# Altium schematic sheet 2022_AESK_UAV_Power.SchDoc(2022_AESK_UAV_Power)
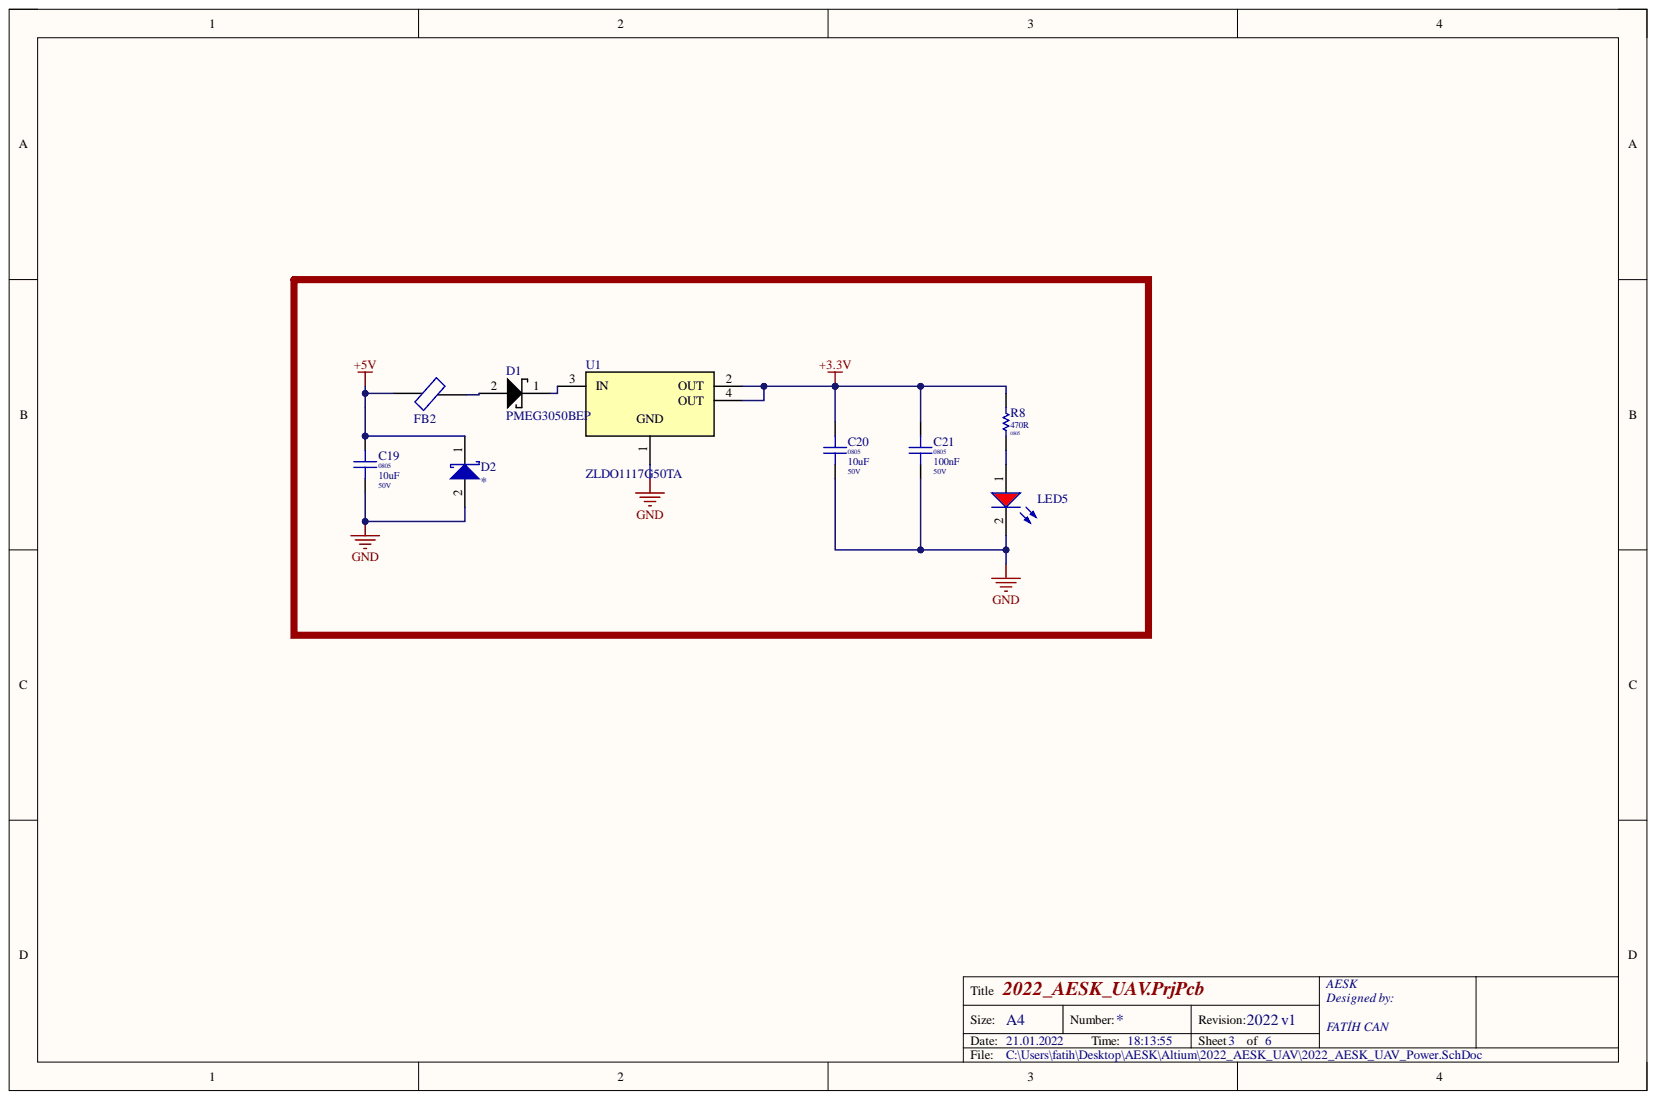
[diagram p0: 2022_AESK_UAV_Power.SchDoc]

## Parts
C19  10uF  Package / Case: 0805  Values: 10uF 50V  Voltage: 50V  [CAPC 0805]
C20  10uF  Package / Case: 0805  Values: 10uF 50V  Voltage: 50V  [CAPC 0805]
C21  100nF  Package / Case: 0805  Values: 100nF 50V  Voltage: 50V  [CAPC 0805]
D1  PMEG3050BEP  [SOD470X100-2N]
D2  (no value)  [DO-214AC, SMA]
FB2  Ferrite Bead  [FCM1608KF-601T03]  (value not drawn)
LED5  (no value)  [LED RED 0805]
R8  470R  Package / Case: 0805  [RESC 0805]
U1  ZLDO1117G50TA  [1117]

## Nets
+3.3V: C20.2 C21.2 R8.2 U1.2(OUT) U1.4(OUT)
+5V: C19.2 D2.1 FB2.2
GND: C19.1 C20.1 C21.1 D2.2 LED5.2 U1.1
NetD1_1: D1.1 U1.3(IN)
NetD1_2: D1.2 FB2.1
NetLED5_1: LED5.1 R8.1

## Library data of the parts
C19 C20 = CAPC0588: Capacitor Ceramic 10uF 50V 0805; Database Table Name: Ceramic Cap$; Value Rating: uF; Category: Capacitor Ceramic; Component Kind: Standard; Part Number: CAPC0588; Value: 10uF; Library Name: Aesk Database Library.DbLib
C21 = CAPC0572: Capacitor Ceramic 100nF 50V 0805; Database Table Name: Ceramic Cap$; Favorites: Favorite; Value Rating: nF; Category: Capacitor Ceramic; Component Kind: Standard; Part Number: CAPC0572; Value: 100nF; Library Name: Aesk Database Library.DbLib
D1 = Schotky Diode: Manufacturer: Nexperia USA; Manufacturer Part Number: PMEG3050BEP,115; Component Kind: Standard; Description: Schotky Diode; Pricing 1: 1=0.44, 10=0.373, 100=0.2786, 500=0.2189, 1000=0.1692 (USD); Stock 1: 62590; Supplier 1: Digi-Key; Supplier Part Number 1: 1727-5207-1-ND; Library Name: 2022_AESK_UAV.SchLib
D2 = Diode0012: Current: FILL!!!; Database Table Name: Diodes$; Diode Type: Schottky; Category: Diode Schottky; Component Kind: Standard; Package / Case: DO-214AC, SMA; Part Number: Diode0012; Speed: FILL!!!; Values: FILL!!!; Voltage - DC Reverse (Vr): FILL!!!; Voltage - Forward (Vf): FILL!!!; Library Name: AESK Database Library.DbLib
FB2 = FCM1608KF-601T03: Component Kind: Standard; Library Name: 2022_AESK_UAV.SchLib
LED5 = LED RED: LED; Component Kind: Standard; Library Name: Diodes_GESK.SchLib
R8 = R0334: 470R 0805; Database Table Name: Resistor$; Category: Resistor; Component Kind: Standard; Part Number: R0334; Value: 470R; Values: 470R; Library Name: Aesk Database Library.DbLib
U1 = ZLDO1117G50TA: IC REG LDO 5V 1A SOT223; Component Kind: Standard; Library Name: UAV_UcusKontrolKartı_Sematik_Kutuphane.SchLib
